# Altium schematic sheet XRACER-POWER-R07.SchDoc(XRACER-POWER-R07)
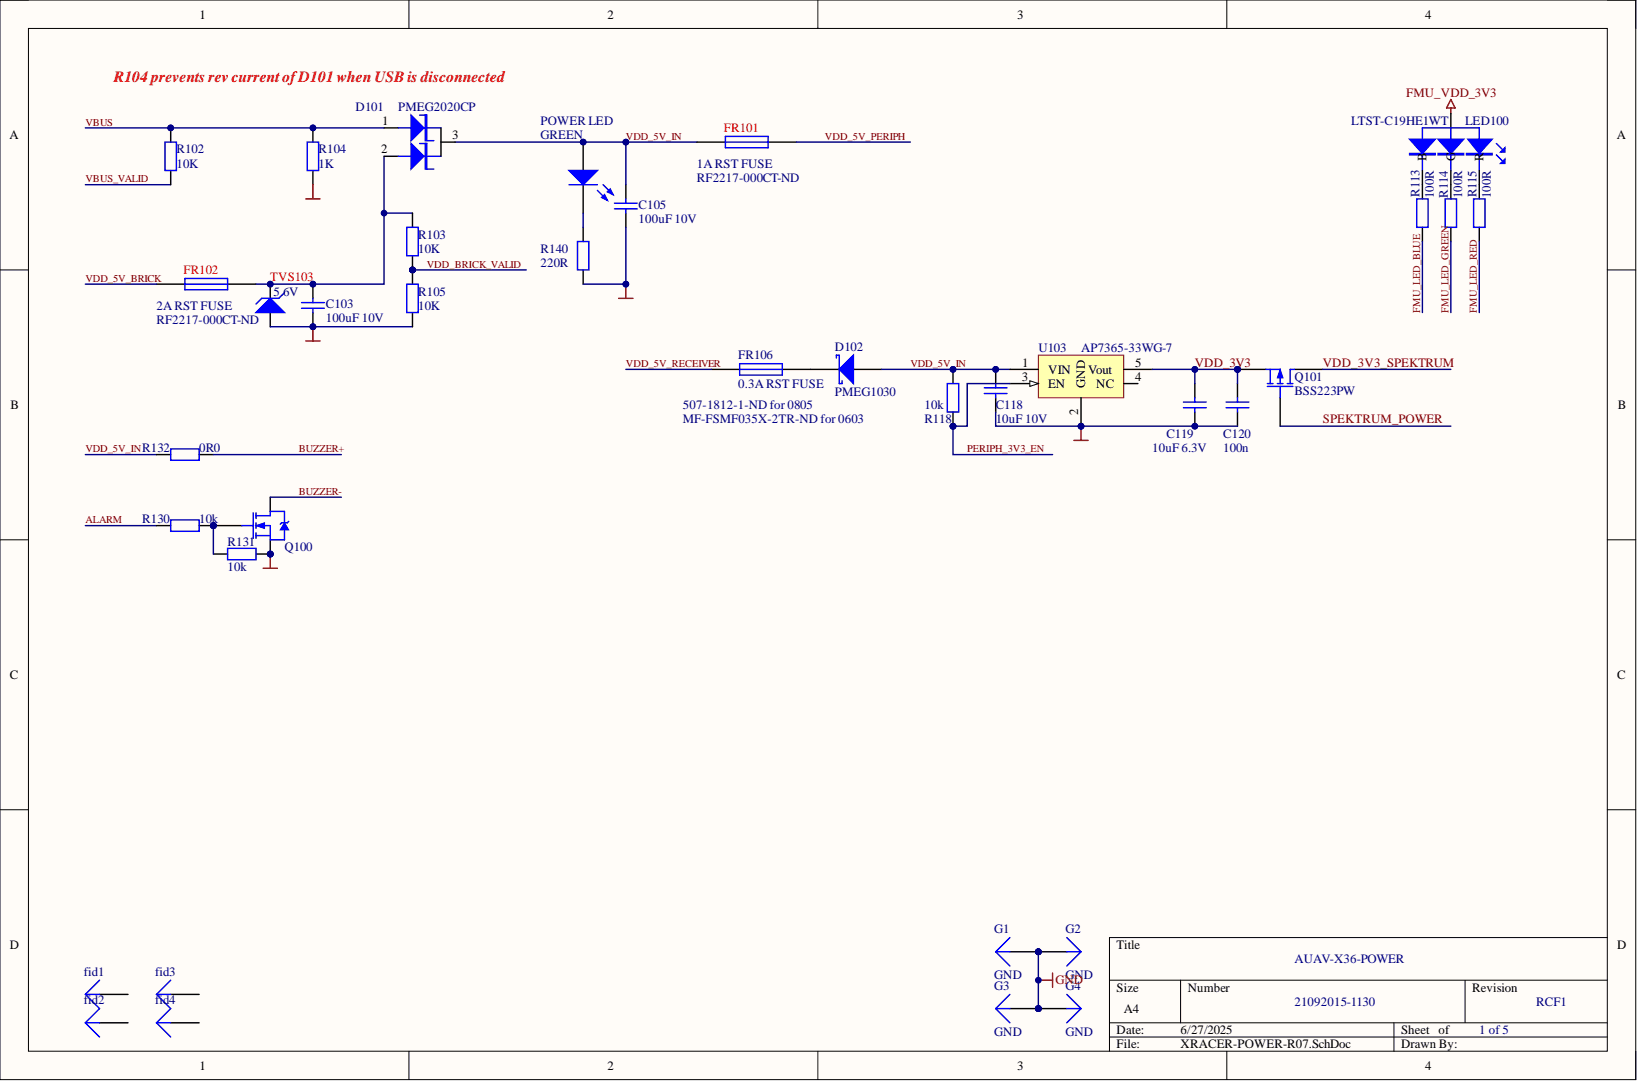
[diagram p0: XRACER-POWER-R07.SchDoc]

## Parts
C103  100uF 10V
C105  100uF 10V
C118  10uF 10V
C119  10uF 6.3V
C120  100n
D101  PMEG2020CP
D102  PMEG1030
fid1  (no value)
fid2  (no value)
fid3  (no value)
fid4  (no value)
FR101  1A RST FUSE
FR102  2A RST FUSE
FR106  0.3A RST FUSE
G1  GND
G2  GND
G3  GND
G4  GND
LED100  LTST-C19HE1WT
POWER_LED  GREEN
Q100  DMG1012UW  (value not drawn)
Q101  BSS223PW
R102  10K
R103  10K
R104  1K
R105  10K
R113  100R
R114  100R
R115  100R
R118  10k
R130  10k
R131  10k
R132  0R0
R140  220R
TVS103  5.6V
U103  AP7365-33WG-7

## Nets
FMU_VDD_3V3: LED100.4
GND: C103.1 C105.1 C118.1 C119.1 C120.1 G1.1 G2.1 G3.1 G4.1 Q100.2 R104.1 R105.2 R131.2 R140.1 TVS103.1 U103.2(GND)
ALARM: R130.2
BUZZER+: R132.1
BUZZER-: Q100.1
FMU_LED_BLUE: R113.1
FMU_LED_GREEN: R114.1
FMU_LED_RED: R115.1
PERIPH_3V3_EN: R118.1 U103.3(EN)
SPEKTRUM_POWER: Q101.3
VBUS: D101.1 R102.2 R104.2
VBUS_VALID: R102.1
VDD_3V3: C119.2 C120.2 Q101.2 U103.5(Vout)
VDD_3V3_SPEKTRUM: Q101.1
VDD_5V_BRICK: FR102.1
VDD_5V_IN: C105.2 C118.2 D101.3 D102.1 FR101.1 POWER_LED.1 R118.2 R132.2 U103.1(VIN)
VDD_5V_PERIPH: FR101.2
VDD_5V_RECEIVER: FR106.2
VDD_BRICK_VALID: R103.2 R105.1
NetC103_2: C103.2 D101.2 FR102.2 R103.1 TVS103.2
NetD102_2: D102.2 FR106.1
NetLED100_1: LED100.1(R) R115.2
NetLED100_2: LED100.2(G) R114.2
NetLED100_3: LED100.3(B) R113.2
NetPOWER LED_2: POWER_LED.2 R140.2
NetQ100_3: Q100.3 R130.1 R131.1

Not connected: fid1 1; fid2 1; fid3 1; fid4 1; U103 4(NC)

## Library data of the parts
C103 C105 C118-C120 = Cap: Capacitor; Value: 100pF; Component Kind: Standard; LatestRevisionDate: 17-Jul-2002; LatestRevisionNote: Re-released for DXP Platform.; Library Name: Miscellaneous Devices.IntLib; PackageDescription: Radial Cap, Thru-Hole; 2 Leads; 0.3 in Pin Spacing; PackageReference: RAD-0.3; Published: 8-Jun-2000; Publisher: Altium Limited
D102 = D Schottky: Schottky Diode; Code_JEDEC: DO-214-AA; Component Kind: Standard; LatestRevisionDate: 17-Jul-2002; LatestRevisionNote: Re-released for DXP Platform.; Library Name: Miscellaneous Devices.IntLib; PackageDescription: DO-214-AA/SMB; 2 C-Bend Leads; Body 5.3 x 3.6 mm, inc. leads (LxW); PackageReference: SMB; Published: 8-Jun-2000; Publisher: Altium Limited
fid1-fid4 G1-G4 = Plug: Component Kind: Standard; Description: Plug; LatestRevisionDate: 17-Jul-2002; LatestRevisionNote: Re-released for DXP Platform.; Library Name: Miscellaneous Connectors.IntLib; Publisher: Altium Limited
FR101 FR102 FR106 = Fuse 1: Fuse; Component Kind: Standard; LatestRevisionDate: 17-Jul-2002; LatestRevisionNote: Re-released for DXP Platform.; Library Name: Miscellaneous Devices.IntLib; PackageDescription: Thru-Hole; 2 Leads; PackageReference: PIN-W2/E2.8; Published: 8-Jun-2000; Publisher: Altium Limited
  FR101 FR102: DigiKey: RF2217-000CT-ND
LED100 = RGB_LED_5MM: Component Kind: Standard; Library Name: My_Altium.SchLib
POWER_LED = LED0: Typical INFRARED GaAs LED; Component Kind: Standard; LatestRevisionDate: 08-Jul-2005; LatestRevisionNote: Mech Layer 1 removed.; Library Name: Miscellaneous Devices.IntLib; PackageDescription: LED; 2 Leads; PackageReference: LED-0; Published: 8-Jun-2000; Publisher: Altium Limited
Q100 = NMOS-2: N-Channel Power MOSFET; Note: Pin 2 is electrically connected to pin 4.; Code_JEDEC: TO-220-AB; Component Kind: Standard; ComponentLink1Description: Manufacturer Link; ComponentLink1URL: http://www.irf.com/; DatasheetDocument: PD-9.814; LatestRevisionDate: 17-Jul-2002; LatestRevisionNote: Re-released for DXP Platform.; Library Name: Miscellaneous Devices.IntLib; PackageReference: TO-220AB; Published: 8-Jun-2000; Publisher: Altium Limited
Q101 = MOSFET-P: P-Channel MOSFET; Component Kind: Standard; LatestRevisionDate: 17-Jul-2002; LatestRevisionNote: Re-released for DXP Platform.; Library Name: Miscellaneous Devices.IntLib; PackageDescription: TO, Flat Index; 3 In-Line, Axial Leads; Body Dia. 4.6mm; Lead Dia. 0.5 mm (max); PackageReference: E3; PackageVersion: 1995/1996; Published: 8-Jun-2000; Publisher: Altium Limited
R102 R103 R105 R113-R115 R118 R130-R132 R140 = Res2: Resistor; Value: 1K; Component Kind: Standard; LatestRevisionDate: 17-Jul-2002; LatestRevisionNote: Re-released for DXP Platform.; Library Name: Miscellaneous Devices.IntLib; PackageReference: AXIAL-0.4; Published: 8-Jun-2000; Publisher: Altium Limited
  R102 R103 R105 R118 R130-R132 R140: PackageDescription: Axial Device, Thru-Hole; 2 Leads; 0.4 in Pin Spacing
R104 = Res2: Resistor; Component Kind: Standard; LatestRevisionDate: 17-Jul-2002; LatestRevisionNote: Re-released for DXP Platform.; Library Name: Miscellaneous Devices.IntLib; PackageDescription: Axial Device, Thru-Hole; 2 Leads; 0.4 in Pin Spacing; PackageReference: AXIAL-0.4; Published: 8-Jun-2000; Value: 1K; Publisher: Altium Limited
TVS103 = D Zener: Zener Diode; Component Kind: Standard; LatestRevisionDate: 17-Jul-2002; LatestRevisionNote: Re-released for DXP Platform.; Library Name: Miscellaneous Devices.IntLib; PackageDescription: Diode, Thru-Hole; 2 Leads; 0.7 in Pin Spacing; PackageReference: DIODE-0.7; Published: 8-Jun-2000; Publisher: Altium Limited
U103 = LP5907-Q1: Component Kind: Standard; Library Name: My_Altium.SchLib
D101 = PMEG2020CP: Component Kind: Standard; Library Name: My_Altium.SchLib
